# Altium schematic sheet PSU_Comms.SchDoc
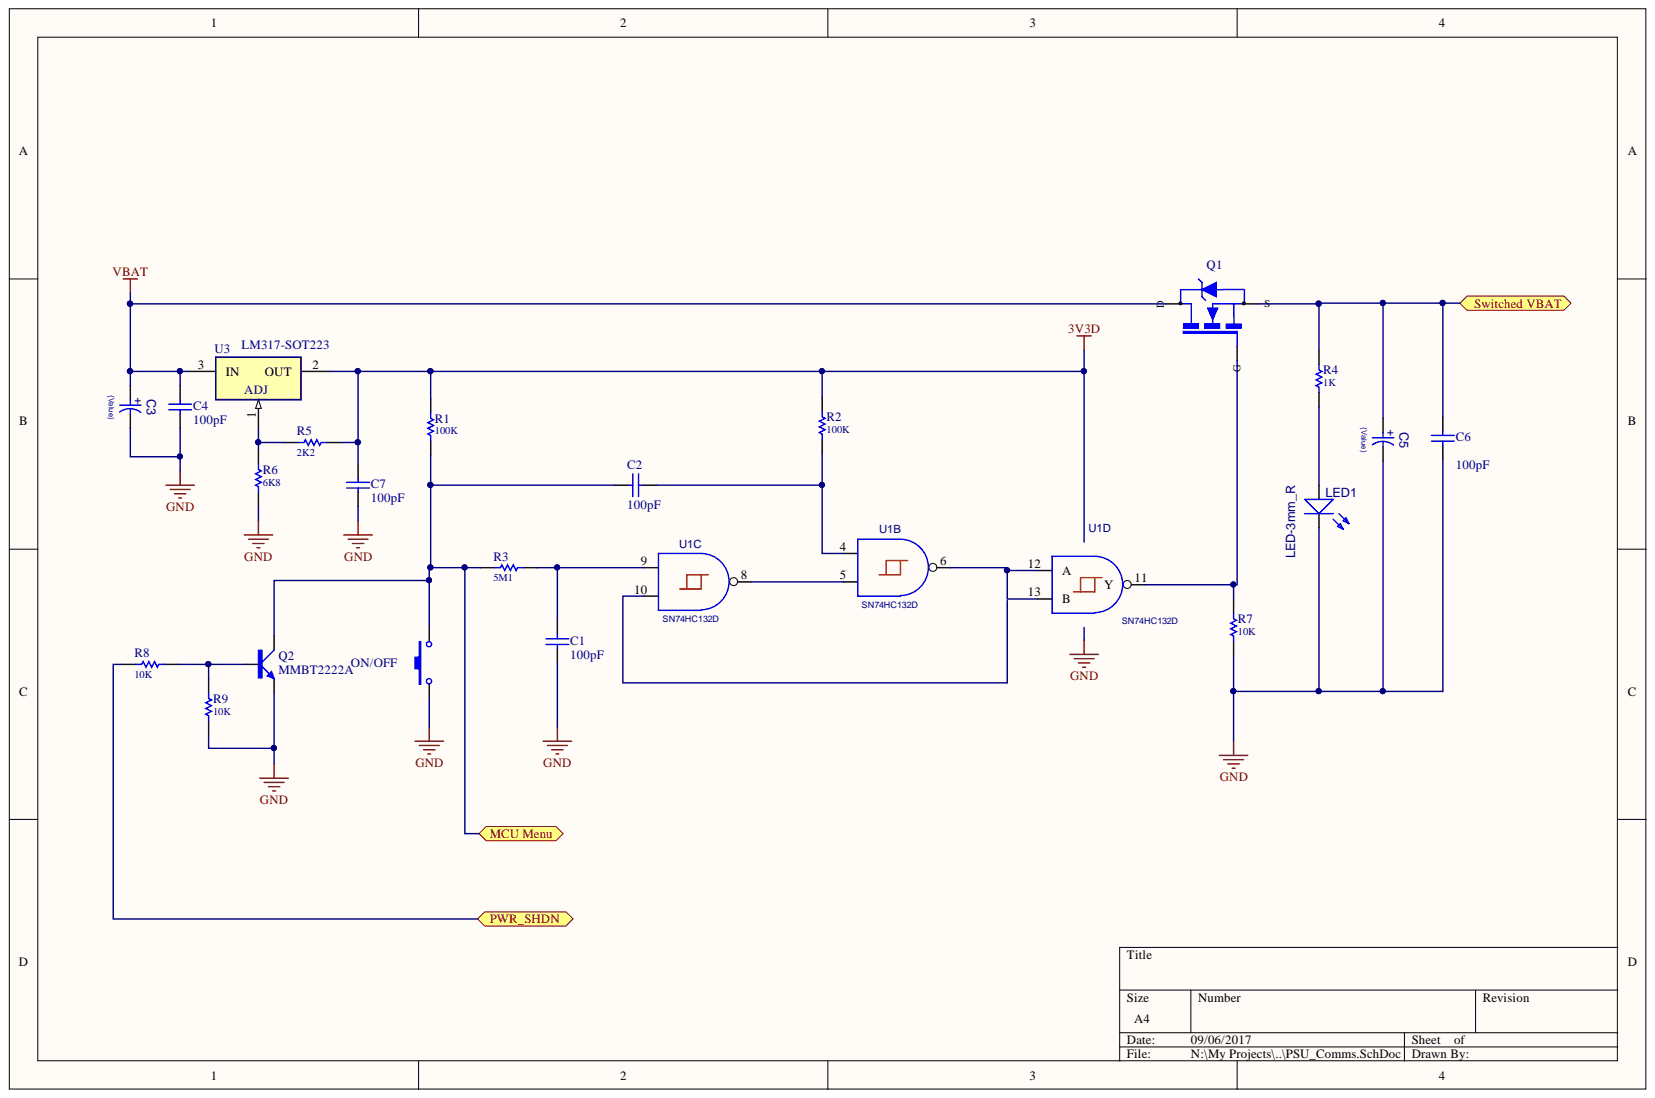
[diagram p0: PSU_Comms.SchDoc]

## Parts
C1  value 100pF  [C-0805]  (hidden comment: Cap 0805)
C2  value 100pF  [C-0805]  (hidden comment: Cap 0805)
C3  value {Value}  [C-TANT#C]  (hidden comment: Cap Tantalum TH)
C4  value 100pF  [C-0805]  (hidden comment: Cap 0805)
C5  value {Value}  [470 uF 10x10.5 HT]  (hidden comment: Cap Elect_SMD 470 uF 10x10.5 HT)
C6  value 100pF  [C-0805]  (hidden comment: Cap 0805)
C7  value 100pF  [C-0805]  (hidden comment: Cap 0805)
LED1  LED-3mm_R  [LED3mm-Through Hole]
ON/OFF  PB PMT  [HDR1X2]  (value not drawn)
Q1  NTD4909NT4G  [DPAK]  (value not drawn)
Q2  MMBT2222A  [SOT-23]
R1  value 100K  [R-0805 - 100K]  (hidden comment: Resistor - SMD 0805)
R2  value 100K  [R-0805 - 100K]  (hidden comment: Resistor - SMD 0805)
R3  value 5M1  [R-0805 - 5M1]  (hidden comment: Resistor - SMD 0805)
R4  value 1K  [R-0805 - 1K]  (hidden comment: Resistor - SMD 0805)
R5  value 2K2  [R-0805 - 2K2]  (hidden comment: Resistor - SMD 0805)
R6  value 6K8  [R-0805 - 6K8]  (hidden comment: Resistor - SMD 0805)
R7  value 10K  [R-0805 - 10K]  (hidden comment: Resistor - SMD 0805)
R8  value 10K  [R-0805 - 10K]  (hidden comment: Resistor - SMD 0805)
R9  value 10K  [R-0805 - 10K]  (hidden comment: Resistor - SMD 0805)
U1  SN74HC132D  [SN74HC132D]  (parts B,C,D)
U3  LM317-SOT223  [LM317_SOT-223]

## Ports
MCU Menu, PWR_SHDN, Switched VBAT

## Nets
3V3D: C7.2 R1.2 R2.2 R5.1 U3.2
GND: C1.1 C3.MINUS C4.1 C5.MINUS C6.1 C7.1 LED1.1 ON/OFF.1 Q2.2 R6.2 R7.1 R9.1
VBAT: C3.PLUS C4.2 Q1.3 U3.3
MCU Menu: C2.2 ON/OFF.2 Q2.3 R1.1 R3.1 ; port MCU Menu
PWR_SHDN: R8.1 ; port PWR_SHDN
Switched VBAT: C5.PLUS C6.2 R4.2 ; port Switched VBAT
NetC1_2: C1.2 R3.2 U1.9
NetC2_1: C2.1 R2.1 U1.4
NetLED1_2: LED1.2 R4.1
NetQ1_1: Q1.1 R7.2 U1.11(Y)
NetQ2_1: Q2.1 R8.2 R9.2
NetR5_2: R5.2 R6.1 U3.1
NetU1_5: U1.5 U1.8
NetU1_6: U1.6 U1.12(A) U1.13(B)

Not connected: Q1 2; U1 10

## Library data of the parts
C1 C2 C4 C6 C7 = Cap 0805: Capacitor SMD; Component Kind: Standard; LatestRevisionDate: 17-Jul-2002; LatestRevisionNote: Re-released for DXP Platform.; PackageReference: C1206; Published: 8-Jun-2000; Library Name: MKM Capacitors.IntLib; Publisher: Altium Limited
C3 = C-TANT#C: Tantalum Capacitor SMD; Component Kind: Standard; Library Name: MKM Capacitors.IntLib
C5 = Cap Elect_SMD 470 uF 10x10.5 HT: SMD Electrolytic Capacitor; Component Kind: Standard; Library Name: MKM Capacitors.IntLib
LED1 = LED-3mm_R: LED 3mm Red; Assy: Loaded; CH: WL-08-2004; CR: VV; Vendor: Fairchild; Vendor P/N: QTLP610C-AG; ComponentHeight: 1.10mm; Component Kind: Standard; Library Name: MKM Optoelectronics.IntLib
ON/OFF = PB PMT: Panel mount Switch TH; Component Kind: Standard; LatestRevisionDate: 17-Jul-2002; LatestRevisionNote: Re-released for DXP Platform.; PackageReference: SPST-2; Published: 24-Mar-1999; Library Name: MKM Switches.IntLib; Publisher: Altium Limited
Q2 = MMBT2222A: NPN Switching Transistor; Component Kind: Standard; ComponentLink1Description: Manufacturer Link; ComponentLink1URL: http://www.semiconductors.philips.com/; ComponentLink2Description: Datasheet; ComponentLink2URL: http://www.semiconductors.philips.com/acrobat/datasheets/MMBT2222A_2.pdf; DatasheetVersion: 11-Apr-2000; LatestRevisionDate: 12-Dec-2006; LatestRevisionNote: IPC-7351 Footprint Added.; PackageDescription: 3-Pin SOT-23 Package 0.95 mm Pitch, 2.3 mm Lead Span; PackageReference: SOT23; PackageVersion: May-1999; Published: 17-Sep-2003; Signal Integrity: MMBT2222A; Simulation: QMMBT2222A; Library Name: MKM Transistors.IntLib; Publisher: Altium Limited
R1 R2 = R0805- 100K: Component Kind: Standard; Description: Resistor - SMD 0805; LatestRevisionDate: 17-Jul-2002; LatestRevisionNote: Re-released for DXP Platform.; PackageReference: AXIAL-0.3; Published: 8-Jun-2000; Signal Integrity: Res; Simulation: RESISTOR; Library Name: MKM Resistors.IntLib; Publisher: Altium Limited
R3 = R0805- 5M1: Component Kind: Standard; Description: Resistor - SMD 0805; LatestRevisionDate: 17-Jul-2002; LatestRevisionNote: Re-released for DXP Platform.; PackageReference: AXIAL-0.3; Published: 8-Jun-2000; Signal Integrity: Res; Simulation: RESISTOR; Library Name: MKM Resistors.IntLib; Publisher: Altium Limited
R4 = R0805- 1K: Component Kind: Standard; Description: Resistor - SMD 0805; LatestRevisionDate: 17-Jul-2002; LatestRevisionNote: Re-released for DXP Platform.; PackageReference: AXIAL-0.3; Published: 8-Jun-2000; Signal Integrity: Res; Simulation: RESISTOR; Library Name: MKM Resistors.IntLib; Publisher: Altium Limited
R5 = R0805- 2K2: Component Kind: Standard; Description: Resistor - SMD 0805; LatestRevisionDate: 17-Jul-2002; LatestRevisionNote: Re-released for DXP Platform.; PackageReference: AXIAL-0.3; Published: 8-Jun-2000; Signal Integrity: Res; Simulation: RESISTOR; Library Name: MKM Resistors.IntLib; Publisher: Altium Limited
R6 = R0805- 6K8: Component Kind: Standard; Description: Resistor - SMD 0805; LatestRevisionDate: 17-Jul-2002; LatestRevisionNote: Re-released for DXP Platform.; PackageReference: AXIAL-0.3; Published: 8-Jun-2000; Signal Integrity: Res; Simulation: RESISTOR; Library Name: MKM Resistors.IntLib; Publisher: Altium Limited
R7-R9 = R0805- 10K: Component Kind: Standard; Description: Resistor - SMD 0805; LatestRevisionDate: 17-Jul-2002; LatestRevisionNote: Re-released for DXP Platform.; PackageReference: AXIAL-0.3; Published: 8-Jun-2000; Signal Integrity: Res; Simulation: RESISTOR; Library Name: MKM Resistors.IntLib; Publisher: Altium Limited
U1 = SN74HC132D: QUAD 2-Input NAND Schmitt Trigger; Assy: Loaded; CH: WL-07-2004; CR: WL; Vendor: Texas Instruments; ComponentHeight: 1.75mm; Component Kind: Standard; VendorP/N: SN74HC132; Library Name: MKM ICs.IntLib
U3 = LM317-SOT223: Adjustable Regulator with 3.7 to 38 V Input and 1.2 to 32 V Output, -40 to 125 degC,; Manufacturer: Texas Instruments; PartNumber: LM317KC; Eco-Plan: Pb-Free (RoHS); Iout(Max)(A): 0.1; Vin(Max)(V): 38; Vin(Min)(V): 3.7; Vout(Max)(V): 32; Vout(Min)(V): 1.2; Code_JEDEC: TO-226-AA; Component Kind: Standard; ComponentLink1Description: Datasheet; ComponentLink1URL: http://www.ti.com/general/docs/lit/getliterature.tsp?genericPartNumber=LM317L&fileType=pdf; ComponentLink2Description: Package Specification; ComponentLink2URL: http://www.ti.com/litv/pdf/msot002a; ComponentLink3Description: URL Link1; ComponentLink3URL: Samples URL:http://www.ti.com/product/lm317l#samples; DatasheetVersion: SLCS144D; Mounting Technology: Through Hole; PackageDescription: Plastic Cylindrical Package, Formed Lead Option (TO-92); PackageReference: LP3; PackageVersion: revC, Oct-2001; Supplier 1: Digi-Key; Supplier 2: Mouser; Supplier Part Number 1: LM317LILPRE3-ND; Supplier Part Number 2: 595-LM317LILPRE3; Library Name: MKM Voltage regulators.IntLib
Q1 = NTD4909NT4G: Component Kind: Standard; Description: NTD4909NT4G; Library Name: MKM Transistors.IntLib
